# Altium schematic sheet EXTRA.SchDoc(EXTRA)
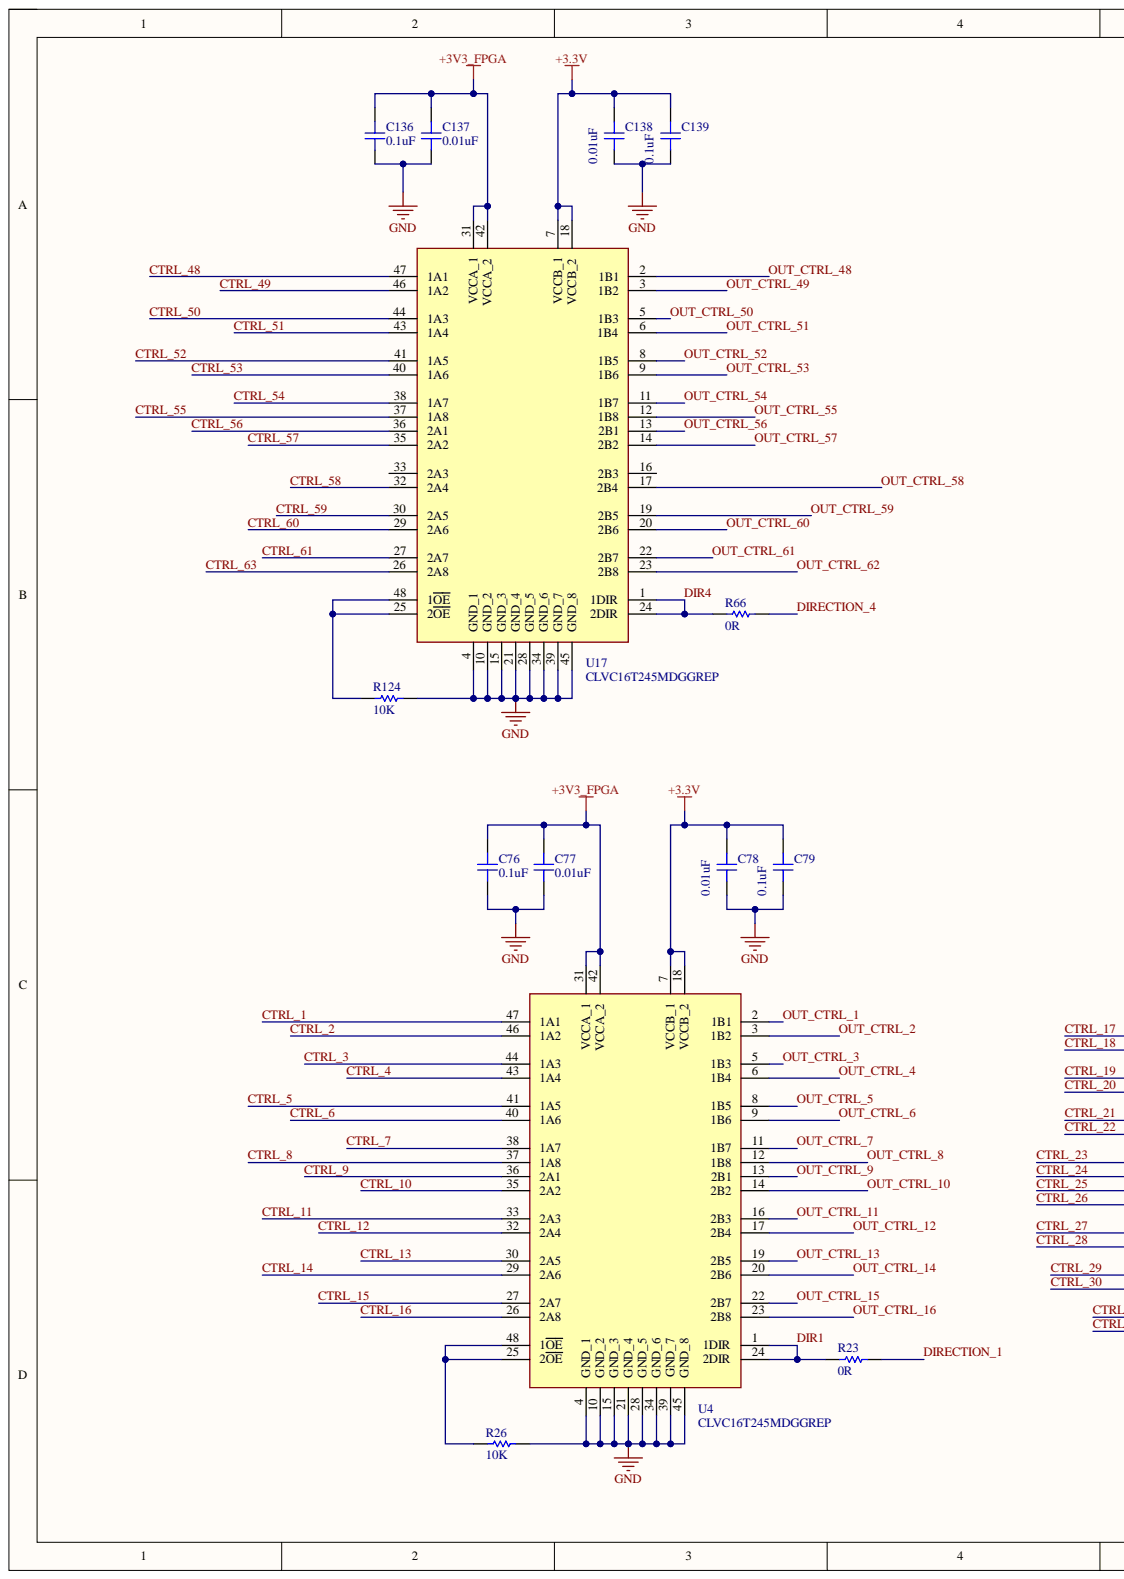
[diagram p0: EXTRA.SchDoc, left]
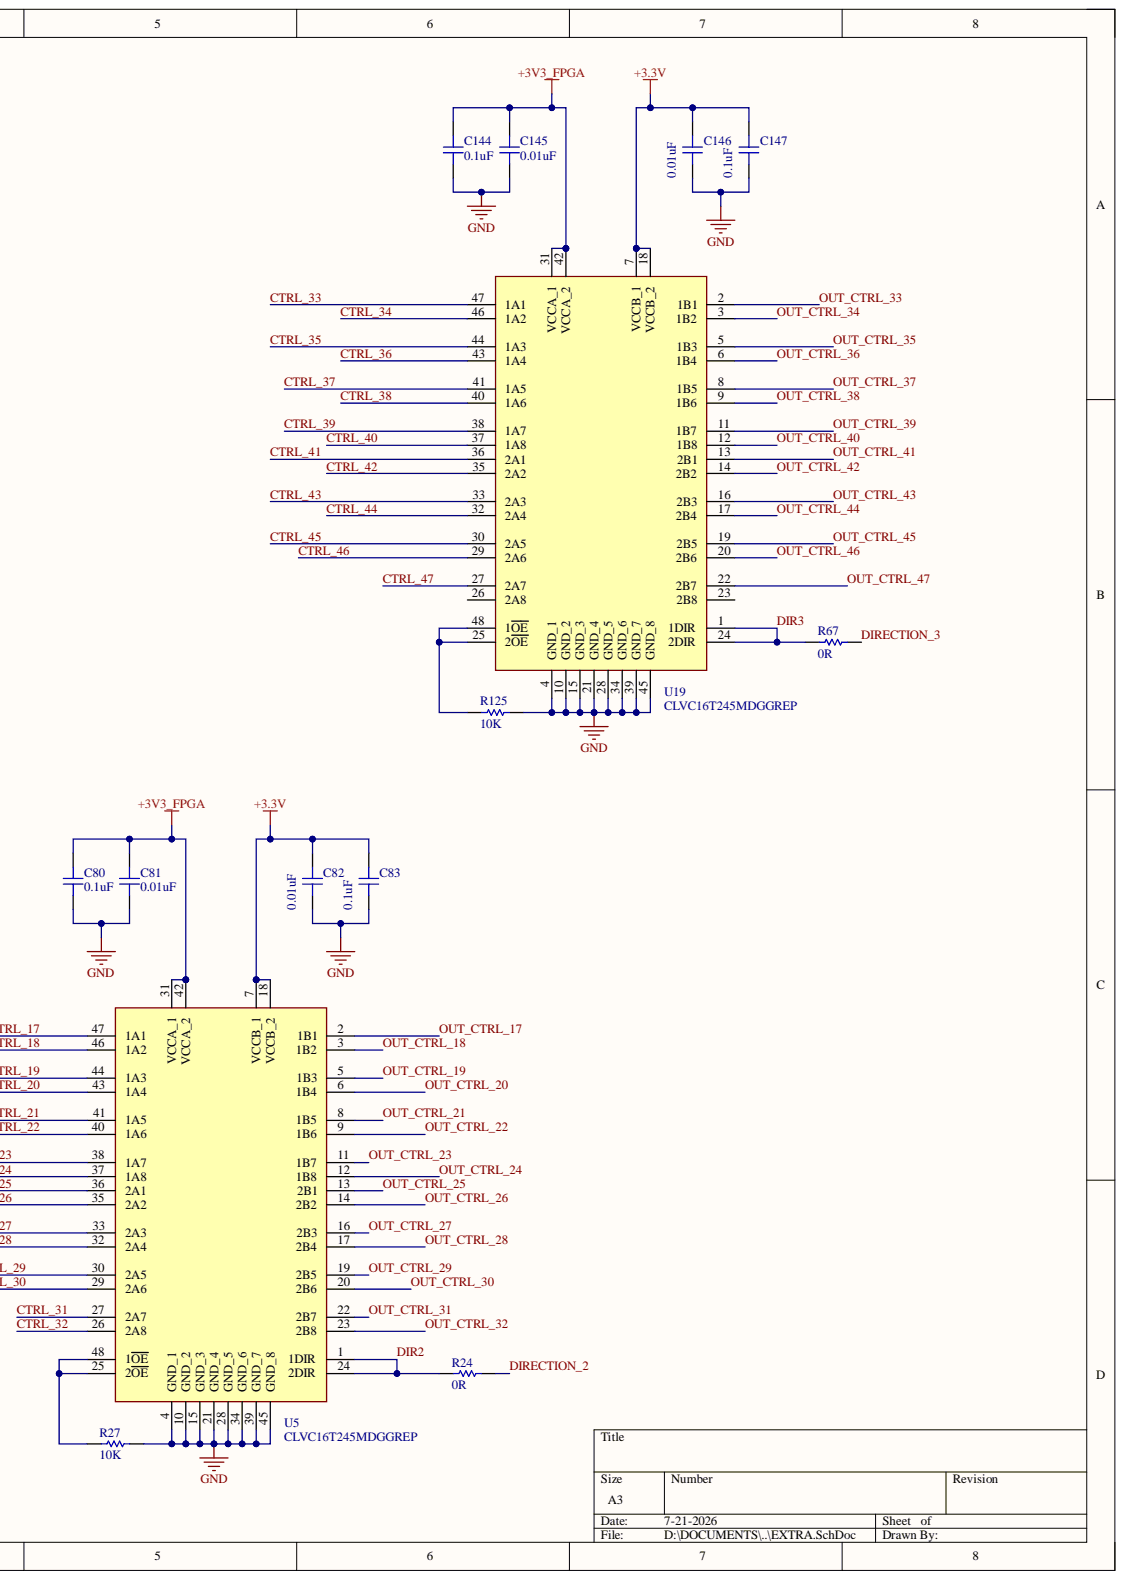
[diagram p1: EXTRA.SchDoc, right]

## Parts
C76  0.1uF  [CAP_0402]
C77  0.01uF  [CAP_0402]
C78  0.01uF  [CAP_0402]
C79  0.1uF  [CAP_0402]
C80  0.1uF  [CAP_0402]
C81  0.01uF  [CAP_0402]
C82  0.01uF  [CAP_0402]
C83  0.1uF  [CAP_0402]
C136  0.1uF  [CAP_0402]
C137  0.01uF  [CAP_0402]
C138  0.01uF  [CAP_0402]
C139  0.1uF  [CAP_0402]
C144  0.1uF  [CAP_0402]
C145  0.01uF  [CAP_0402]
C146  0.01uF  [CAP_0402]
C147  0.1uF  [CAP_0402]
R23  0R  [RES_0402]
R24  0R  [RES_0402]
R26  10K  [RES_0402]
R27  10K  [RES_0402]
R66  0R  [RES_0402]
R67  0R  [RES_0402]
R124  10K  [RES_0402]
R125  10K  [RES_0402]
U4  CLVC16T245MDGGREP  [SOP50P810X120-48N]
U5  CLVC16T245MDGGREP  [SOP50P810X120-48N]
U17  CLVC16T245MDGGREP  [SOP50P810X120-48N]
U19  CLVC16T245MDGGREP  [SOP50P810X120-48N]

## Nets
+3.3V: C78.2 C79.2 C82.2 C83.2 C138.2 C139.2 C146.2 C147.2 U4.7(VCCB_1) U4.18(VCCB_2) U5.7(VCCB_1) U5.18(VCCB_2) U17.7(VCCB_1) U17.18(VCCB_2) U19.7(VCCB_1) U19.18(VCCB_2)
+3V3_FPGA: C76.2 C77.2 C80.2 C81.2 C136.2 C137.2 C144.2 C145.2 U4.31(VCCA_1) U4.42(VCCA_2) U5.31(VCCA_1) U5.42(VCCA_2) U17.31(VCCA_1) U17.42(VCCA_2) U19.31(VCCA_1) U19.42(VCCA_2)
GND: C76.1 C77.1 C78.1 C79.1 C80.1 C81.1 C82.1 C83.1 C136.1 C137.1 C138.1 C139.1 C144.1 C145.1 C146.1 C147.1 R26.1 R27.1 R124.1 R125.1 U4.4(GND_1) U4.10(GND_2) U4.15(GND_3) U4.21(GND_4) U4.28(GND_5) U4.34(GND_6) U4.39(GND_7) U4.45(GND_8) U5.4(GND_1) U5.10(GND_2) U5.15(GND_3) U5.21(GND_4) U5.28(GND_5) U5.34(GND_6) U5.39(GND_7) U5.45(GND_8) U17.4(GND_1) U17.10(GND_2) U17.15(GND_3) U17.21(GND_4) U17.28(GND_5) U17.34(GND_6) U17.39(GND_7) U17.45(GND_8) U19.4(GND_1) U19.10(GND_2) U19.15(GND_3) U19.21(GND_4) U19.28(GND_5) U19.34(GND_6) U19.39(GND_7) U19.45(GND_8)
CTRL_1: U4.47(1A1)
CTRL_2: U4.46(1A2)
CTRL_3: U4.44(1A3)
CTRL_4: U4.43(1A4)
CTRL_5: U4.41(1A5)
CTRL_6: U4.40(1A6)
CTRL_7: U4.38(1A7)
CTRL_8: U4.37(1A8)
CTRL_9: U4.36(2A1)
CTRL_10: U4.35(2A2)
CTRL_11: U4.33(2A3)
CTRL_12: U4.32(2A4)
CTRL_13: U4.30(2A5)
CTRL_14: U4.29(2A6)
CTRL_15: U4.27(2A7)
CTRL_16: U4.26(2A8)
CTRL_17: U5.47(1A1)
CTRL_18: U5.46(1A2)
CTRL_19: U5.44(1A3)
CTRL_20: U5.43(1A4)
CTRL_21: U5.41(1A5)
CTRL_22: U5.40(1A6)
CTRL_23: U5.38(1A7)
CTRL_24: U5.37(1A8)
CTRL_25: U5.36(2A1)
CTRL_26: U5.35(2A2)
CTRL_27: U5.33(2A3)
CTRL_28: U5.32(2A4)
CTRL_29: U5.30(2A5)
CTRL_30: U5.29(2A6)
CTRL_31: U5.27(2A7)
CTRL_32: U5.26(2A8)
CTRL_33: U19.47(1A1)
CTRL_34: U19.46(1A2)
CTRL_35: U19.44(1A3)
CTRL_36: U19.43(1A4)
CTRL_37: U19.41(1A5)
CTRL_38: U19.40(1A6)
CTRL_39: U19.38(1A7)
CTRL_40: U19.37(1A8)
CTRL_41: U19.36(2A1)
CTRL_42: U19.35(2A2)
CTRL_43: U19.33(2A3)
CTRL_44: U19.32(2A4)
CTRL_45: U19.30(2A5)
CTRL_46: U19.29(2A6)
CTRL_47: U19.27(2A7)
CTRL_48: U17.47(1A1)
CTRL_49: U17.46(1A2)
CTRL_50: U17.44(1A3)
CTRL_51: U17.43(1A4)
CTRL_52: U17.41(1A5)
CTRL_53: U17.40(1A6)
CTRL_54: U17.38(1A7)
CTRL_55: U17.37(1A8)
CTRL_56: U17.36(2A1)
CTRL_57: U17.35(2A2)
CTRL_58: U17.32(2A4)
CTRL_59: U17.30(2A5)
CTRL_60: U17.29(2A6)
CTRL_61: U17.27(2A7)
CTRL_63: U17.26(2A8)
DIR1: R23.1 U4.1(1DIR) U4.24(2DIR)
DIR2: R24.1 U5.1(1DIR) U5.24(2DIR)
DIR3: R67.1 U19.1(1DIR) U19.24(2DIR)
DIR4: R66.1 U17.1(1DIR) U17.24(2DIR)
DIRECTION_1: R23.2
DIRECTION_2: R24.2
DIRECTION_3: R67.2
DIRECTION_4: R66.2
OUT_CTRL_1: U4.2(1B1)
OUT_CTRL_2: U4.3(1B2)
OUT_CTRL_3: U4.5(1B3)
OUT_CTRL_4: U4.6(1B4)
OUT_CTRL_5: U4.8(1B5)
OUT_CTRL_6: U4.9(1B6)
OUT_CTRL_7: U4.11(1B7)
OUT_CTRL_8: U4.12(1B8)
OUT_CTRL_9: U4.13(2B1)
OUT_CTRL_10: U4.14(2B2)
OUT_CTRL_11: U4.16(2B3)
OUT_CTRL_12: U4.17(2B4)
OUT_CTRL_13: U4.19(2B5)
OUT_CTRL_14: U4.20(2B6)
OUT_CTRL_15: U4.22(2B7)
OUT_CTRL_16: U4.23(2B8)
OUT_CTRL_17: U5.2(1B1)
OUT_CTRL_18: U5.3(1B2)
OUT_CTRL_19: U5.5(1B3)
OUT_CTRL_20: U5.6(1B4)
OUT_CTRL_21: U5.8(1B5)
OUT_CTRL_22: U5.9(1B6)
OUT_CTRL_23: U5.11(1B7)
OUT_CTRL_24: U5.12(1B8)
OUT_CTRL_25: U5.13(2B1)
OUT_CTRL_26: U5.14(2B2)
OUT_CTRL_27: U5.16(2B3)
OUT_CTRL_28: U5.17(2B4)
OUT_CTRL_29: U5.19(2B5)
OUT_CTRL_30: U5.20(2B6)
OUT_CTRL_31: U5.22(2B7)
OUT_CTRL_32: U5.23(2B8)
OUT_CTRL_33: U19.2(1B1)
OUT_CTRL_34: U19.3(1B2)
OUT_CTRL_35: U19.5(1B3)
OUT_CTRL_36: U19.6(1B4)
OUT_CTRL_37: U19.8(1B5)
OUT_CTRL_38: U19.9(1B6)
OUT_CTRL_39: U19.11(1B7)
OUT_CTRL_40: U19.12(1B8)
OUT_CTRL_41: U19.13(2B1)
OUT_CTRL_42: U19.14(2B2)
OUT_CTRL_43: U19.16(2B3)
OUT_CTRL_44: U19.17(2B4)
OUT_CTRL_45: U19.19(2B5)
OUT_CTRL_46: U19.20(2B6)
OUT_CTRL_47: U19.22(2B7)
OUT_CTRL_48: U17.2(1B1)
OUT_CTRL_49: U17.3(1B2)
OUT_CTRL_50: U17.5(1B3)
OUT_CTRL_51: U17.6(1B4)
OUT_CTRL_52: U17.8(1B5)
OUT_CTRL_53: U17.9(1B6)
OUT_CTRL_54: U17.11(1B7)
OUT_CTRL_55: U17.12(1B8)
OUT_CTRL_56: U17.13(2B1)
OUT_CTRL_57: U17.14(2B2)
OUT_CTRL_58: U17.17(2B4)
OUT_CTRL_59: U17.19(2B5)
OUT_CTRL_60: U17.20(2B6)
OUT_CTRL_61: U17.22(2B7)
OUT_CTRL_62: U17.23(2B8)
NetR26_2: R26.2 U4.25(2OE) U4.48(1OE)
NetR27_2: R27.2 U5.25(2OE) U5.48(1OE)
NetR124_2: R124.2 U17.25(2OE) U17.48(1OE)
NetR125_2: R125.2 U19.25(2OE) U19.48(1OE)

Not connected: U17 16(2B3) 33(2A3); U19 23(2B8) 26(2A8)

## Library data of the parts
C76-C83 C136-C139 C144-C147 = CAP_0402_50V: C0402_50V; Component Kind: Standard; Library Name: FPGA_LIB.SchLib
R23 R24 R26 R27 R66 R67 R124 R125 = RES_0402: R0402; Component Kind: Standard; Library Name: FPGA_LIB.SchLib
U4 U5 U17 U19 = CLVC16T245MDGGREP: IC; Manufacturer_Name: Texas Instruments; Component Kind: Standard; Datasheet Link: http://www.ti.com/lit/gpn/sn74lvc16t245-ep; Height: 1.2mm; Library Name: FPGA_LIB.SchLib; Manufacturer_Part_Number: CLVC16T245MDGGREP; Mouser Part Number: 595-CLC16T245MDGGREP; Mouser Price/Stock: https://www.mouser.co.uk/ProductDetail/Texas-Instruments/CLVC16T245MDGGREP?qs=c1nyi2h1TsquyUDOPWgPnw%3D%3D
